# Altium schematic sheet main-arduino.SchDoc(main-arduino)
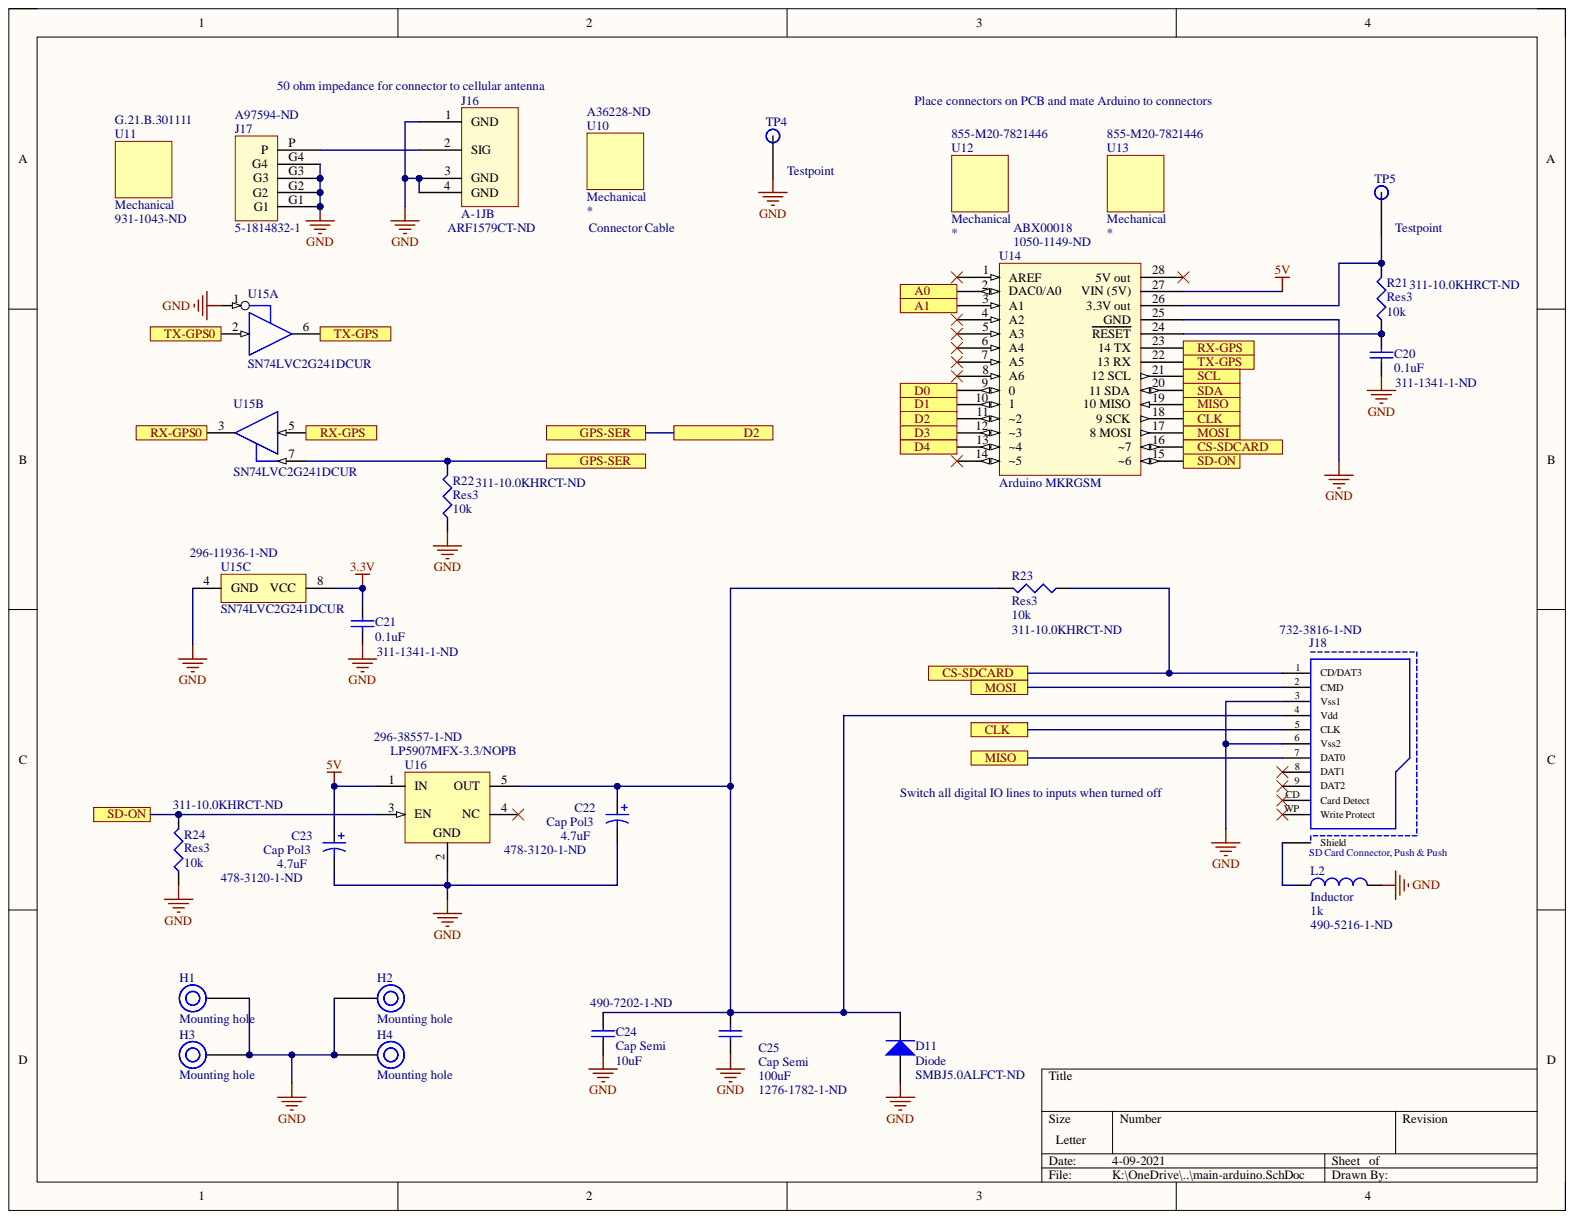
[diagram p0: main-arduino.SchDoc]

## Parts
C20  value 0.1uF  Supplier Part Number 1: 311-1341-1-ND  [1608[0603]]  (hidden comment: Cap Semi)
C21  value 0.1uF  Supplier Part Number 1: 311-1341-1-ND  [1608[0603]]  (hidden comment: Cap Semi)
C22  Cap Pol3  value 4.7uF  [1206-pol]
C23  Cap Pol3  value 4.7uF  Supplier Part Number 1: 478-3120-1-ND  [1206-pol]
C24  Cap Semi  value 10uF  Capacitance: 10uF  Supplier Part Number 1: 490-7202-1-ND  [1608[0603]]
C25  Cap Semi  value 100uF  Capacitance: 100uF  [C1206]
D11  Diode  Supplier Part Number 1: SMBJ5.0ALFCT-ND  [smbj]
H1  Mounting hole  [M3 hole]  (no BOM)
H2  Mounting hole  [M3 hole]  (no BOM)
H3  Mounting hole  [M3 hole]  (no BOM)
H4  Mounting hole  [M3 hole]  (no BOM)
J16  A-1JB  Supplier Part Number 1: ARF1579CT-ND  [A-1JB]
J17  5-1814832-1  Supplier Part Number 1: A97594-ND  [5-1814832-1]
J18  SD Card Connector, Push & Push  Supplier Part Number 1: 732-3816-1-ND  [693061010911]
L2  Inductor  value 1k  [J1-0603]
R21  Res3  value 10k  Supplier Part Number 1: 311-10.0KHRCT-ND  [J1-0603]
R22  Res3  value 10k  Supplier Part Number 1: 311-10.0KHRCT-ND  [J1-0603]
R23  Res3  value 10k  [J1-0603]
R24  Res3  value 10k  Supplier Part Number 1: 311-10.0KHRCT-ND  [J1-0603]
TP4  Testpoint  [testpoint]  (no BOM)
TP5  Testpoint  [testpoint]  (no BOM)
U10  Mechanical  Supplier Part Number 2: A36228-ND  (mechanical)
U11  Mechanical  Manufacturer Part Number: G.21.B.301111  Supplier Part Number 1: 931-1043-ND  (mechanical)
U12  Mechanical  Supplier Part Number 2: 855-M20-7821446  (mechanical)
U13  Mechanical  Supplier Part Number 2: 855-M20-7821446  (mechanical)
U14  Arduino MKRGSM  Manufacturer: Arduino  Supplier Part Number 1: 1050-1149-ND  [MKRGSM]
U15  SN74LVC2G241DCUR  [DCU0008A_M]  (parts A,B,C)
U16  LP5907MFX-3.3/NOPB  [DBV0005A_L]

## Ports
A0, A1, CLK, CS-SDCARD, D0, D1, D2, D3, D4, GPS-SER, MISO, MOSI, RX-GPS, RX-GPS0, SCL, SD-ON, SDA, TX-GPS, TX-GPS0

## Nets
3.3V: C21.1 U15.8(VCC)
5V: C23.1 U14.27(VIN_(5V)) U16.1(IN)
GND: C20.2 C21.2 C22.2 C23.2 C24.1 C25.1 D11.1 H1.1 H2.1 H3.1 H4.1 J16.1(GND) J16.3(GND) J16.4(GND) J17.G1 J17.G2 J17.G3 J17.G4 J18.3(Vss1) J18.6(Vss2) L2.2 R22.1 R24.1 TP4.1 U14.25(GND) U15.1 U15.4(GND) U16.2
A0: U14.2(DAC0/A0) ; port A0
A1: U14.3(A1) ; port A1
CLK: J18.5(CLK) U14.18(9_SCK) ; port CLK
CS-SDCARD: J18.1(CD/DAT3) R23.1 U14.16(~7) ; port CS-SDCARD
D0: U14.9 ; port D0
D1: U14.10 ; port D1
D2: R22.2 U14.11(~2) U15.7 ; port D2, port GPS-SER
D3: U14.12(~3) ; port D3
D4: U14.13(~4) ; port D4
MISO: J18.7(DAT0) U14.19(10_MISO) ; port MISO
MOSI: J18.2(CMD) U14.17(8_MOSI) ; port MOSI
RX-GPS: U14.23(14_TX) U15.5 ; port RX-GPS
RX-GPS0: U15.3 ; port RX-GPS0
SCL: U14.21(12_SCL) ; port SCL
SD-ON: R24.2 U14.15(~6) U16.3(EN) ; port SD-ON
SDA: U14.20(11_SDA) ; port SDA
TX-GPS: U14.22(13_RX) U15.6 ; port TX-GPS
TX-GPS0: U15.2 ; port TX-GPS0
NetC20_1: C20.1 R21.1 U14.24(RESET)
NetC22_1: C22.1 C24.2 C25.2 D11.2 J18.4(Vdd) R23.2 U16.5(OUT)
NetJ16_2: J16.2(SIG) J17.P
NetJ18_Shield: J18.Shield L2.1
NetR21_2: R21.2 TP5.1 U14.26(3.3V_out)

Not connected: J18 8(DAT1) 9(DAT2) CD WP(Write_Protect); U14 1(AREF) 4(A2) 5(A3) 6(A4) 7(A5) 8(A6) 14(~5) 28(5V_out); U16 4(NC)

## Library data of the parts
C20 C21 = Cap Semi: Capacitor (Semiconductor SIM Model); Manufacturer: Yageo; Manufacturer Part Number: CC0603KRX7R8BB104; Capacitance: 100nF; Dielectric: X7R; Tolerance: 10%; Voltage: 25V; Case Code (Imperial): 0603; Case Code (Metric): 1608; Case/Package: 0603; Component Kind: Standard; ComponentLink1Description: http://www.samsungsem.com/servlet/FileDownload?type=spec&file=CL10B104KB8NNNC.pdf; ComponentLink1URL: http://www.samsungsem.com/servlet/FileDownload?type=spec&file=CL10B104KB8NNNC.pdf; ComponentLink2Description: https://datasheet.ciiva.com/11786/0900766b80c10176-11786120.pdf?src-supplier=RSComponents; ComponentLink2URL: https://datasheet.ciiva.com/11786/0900766b80c10176-11786120.pdf?src-supplier=RSComponents; ComponentLink3Description: https://datasheet.ciiva.com/144/mlccorderingcode-144075.pdf?src-supplier=Digi-Key; ComponentLink3URL: https://datasheet.ciiva.com/144/mlccorderingcode-144075.pdf?src-supplier=Digi-Key; ComponentLink4Description: https://datasheet.ciiva.com/17434/upy-gphc-x7r-6-3v-to-50v-8-17434263.pdf?src-supplier=Avnet+Europe; ComponentLink4URL: https://datasheet.ciiva.com/17434/upy-gphc-x7r-6-3v-to-50v-8-17434263.pdf?src-supplier=Avnet+Europe; ComponentLink5Description: https://datasheet.ciiva.com/26829/getdatasheetpartid-403577-26829431.pdf?src-supplier=Verical; ComponentLink5URL: https://datasheet.ciiva.com/26829/getdatasheetpartid-403577-26829431.pdf?src-supplier=Verical; ComponentLink6Description: https://datasheet.ciiva.com/11587/upy-gphc-x7r-6-3v-to-50v-8-11587208.pdf?src-supplier=Avnet; ComponentLink6URL: https://datasheet.ciiva.com/11587/upy-gphc-x7r-6-3v-to-50v-8-11587208.pdf?src-supplier=Avnet; ComponentLink7Description: https://datasheet.ciiva.com/13389/upy-gphc-x7r-6-3v-to-50v-8-13389352.pdf?src-supplier=Mouser; ComponentLink7URL: https://datasheet.ciiva.com/13389/upy-gphc-x7r-6-3v-to-50v-8-13389352.pdf?src-supplier=Mouser; ComponentLink8Description: https://datasheet.ciiva.com/28450/1489800-28450584.pdf?src-supplier=Element14; ComponentLink8URL: https://datasheet.ciiva.com/28450/1489800-28450584.pdf?src-supplier=Element14; ComponentLink9Description: https://datasheet.ciiva.com/144/mlccorderingcode-144073.pdf?src-supplier=Digi-Key; ComponentLink9URL: https://datasheet.ciiva.com/144/mlccorderingcode-144073.pdf?src-supplier=Digi-Key; ComponentLink10Description: https://datasheet.ciiva.com/144/mlccorderingcode-144074.pdf?src-supplier=Digi-Key; ComponentLink10URL: https://datasheet.ciiva.com/144/mlccorderingcode-144074.pdf?src-supplier=Digi-Key; ComponentLink11Description: https://datasheet.ciiva.com/7978/1489800-7978155.pdf?src-supplier=Element14; ComponentLink11URL: https://datasheet.ciiva.com/7978/1489800-7978155.pdf?src-supplier=Element14; Composition: Ceramic; Depth: 0.8mm; Halogen Free: Halogen Free; Height: 0.8mm; Lead Free: Lead Free; Length: 1.6mm; Library Name: Miscellaneous Devices.IntLib; Max Operating Temperature: 125°C; Min Operating Temperature: -55°C; Mount: Surface Mount; Number of Pins: 2; Package Quantity: 1; Packaging: Tape and Reel; Pricing 1: 1=0.10000, 10=0.05000, 100=0.02220, 500=0.01584, 1000=0.01245 (USD); Radiation Hardening: No; REACH SVHC: Unknown; RoHS: Yes; Signal Integrity: Cap Semi; Simulation: CAP; Stock 1: 22812167; Supplier 1: Digi-Key; Termination: SMD/SMT; Thickness: 0.035inch; Voltage Rating: 25V; Voltage Rating (DC): 25V; Width: 0.8mm
C22 C23 = Cap Pol3: Polarized Capacitor (Surface Mount); Manufacturer: AVX Corporation; Manufacturer Part Number: NOJA475M010RWJ; Manufacturer Size Code: A; Dissipation Factor: 6%; Tolerance: ±20%; Category: Capacitors; Component Kind: Standard; ComponentLink1Description: http://datasheets.avx.com/NOJ.pdf; ComponentLink1URL: http://datasheets.avx.com/NOJ.pdf; Features: -; Height - Seated (Max): 0.071" (1.80mm); Library Name: Miscellaneous Devices.IntLib; Mounting Type: Surface Mount; Operating Temperature: -55°C ~ 105°C; Package / Case: 1206 (3216 Metric); Packaging: Cut Tape (CT); Part Status: Active; Pricing 1: 1=0.68, 10=0.485, 100=0.319, 500=0.2363, 1000=0.20086 (CAD); RoHS: RoHS Compliant; Signal Integrity: Cap Pol; Simulation: CAP; Size / Dimension: 0.126" L x 0.063" W (3.20mm x 1.60mm); Stock 1: 5356; Supplier 1: Digi-Key; Supplier Device Package: 1206 (3216 Metric)
  C22: Supplier Part Number 1: 478-3120-1-ND
C24 = Cap Semi: Capacitor (Semiconductor SIM Model); Manufacturer: Murata; Manufacturer Part Number: GRM188R61E106MA73D; Dielectric: X5R; Tolerance: 20%; Voltage: 25V; Case Code (Imperial): 0603; Case Code (Metric): 1608; Case/Package: 0603; Component Kind: Standard; ComponentLink1Description: http://www.yuden.co.jp/productdata/catalog/en/mlcc06_e.pdf; ComponentLink1URL: http://www.yuden.co.jp/productdata/catalog/en/mlcc06_e.pdf; ComponentLink2Description: https://datasheet.ciiva.com/25710/cl31a106kahnnne-25710837.pdf?src-supplier=Digi-Key; ComponentLink2URL: https://datasheet.ciiva.com/25710/cl31a106kahnnne-25710837.pdf?src-supplier=Digi-Key; ComponentLink3Description: https://datasheet.ciiva.com/15195/getdatasheetpartid-355210-15195990.pdf?src-supplier=Verical; ComponentLink3URL: https://datasheet.ciiva.com/15195/getdatasheetpartid-355210-15195990.pdf?src-supplier=Verical; ComponentLink4Description: https://datasheet.ciiva.com/29201/452519-da-01-en-vs-kondensator-1206-29201124.pdf?src-supplier=Conrad; ComponentLink4URL: https://datasheet.ciiva.com/29201/452519-da-01-en-vs-kondensator-1206-29201124.pdf?src-supplier=Conrad; ComponentLink5Description: https://datasheet.ciiva.com/22619/c02e-22619674.pdf?src-supplier=Element14; ComponentLink5URL: https://datasheet.ciiva.com/22619/c02e-22619674.pdf?src-supplier=Element14; ComponentLink6Description: https://datasheet.ciiva.com/22776/608985-22776426.pdf?src-supplier=Farnell; ComponentLink6URL: https://datasheet.ciiva.com/22776/608985-22776426.pdf?src-supplier=Farnell; ComponentLink7Description: https://datasheet.ciiva.com/22776/c02e-22776427.pdf?src-supplier=Farnell; ComponentLink7URL: https://datasheet.ciiva.com/22776/c02e-22776427.pdf?src-supplier=Farnell; ComponentLink8Description: https://datasheet.ciiva.com/14906/partnumbering-e-01-14906429.pdf?src-supplier=Digi-Key; ComponentLink8URL: https://datasheet.ciiva.com/14906/partnumbering-e-01-14906429.pdf?src-supplier=Digi-Key; ComponentLink9Description: https://datasheet.ciiva.com/14906/partnumbering-e-01-14906431.pdf?src-supplier=Digi-Key; ComponentLink9URL: https://datasheet.ciiva.com/14906/partnumbering-e-01-14906431.pdf?src-supplier=Digi-Key; Composition: Ceramic; Depth: 0.8mm; Height: 0.8mm; Lead Free: Lead Free; Length: 1.6mm; Library Name: Miscellaneous Devices.IntLib; Max Operating Temperature: 85°C; Min Operating Temperature: -55°C; Mount: Surface Mount; Number of Pins: 2; Package Quantity: 1; Packaging: Tape and Reel; Pricing 1: 1=0.42000, 10=0.29300, 100=0.17560, 500=0.13172, 1000=0.11123 (USD); REACH SVHC: No SVHC; RoHS: Yes; Signal Integrity: Cap Semi; Simulation: CAP; Stock 1: 278450; Supplier 1: Digi-Key; Termination: SMD/SMT; Thickness: 0.031inch; Voltage Rating: 25V; Voltage Rating (DC): 25V; Weight: 0.000222oz; Width: 0.031inch
C25 = Cap Semi: Capacitor (Semiconductor SIM Model); Manufacturer: Samsung; Manufacturer Part Number: CL31A107MQHNNNE; Dielectric: X5R; Material: Ceramic; Tolerance: 20%; Voltage: 6.3V; Case Code (Imperial): 1206; Case Code (Metric): 3216; Case/Package: 1206; Component Kind: Standard; ComponentLink1Description: https://datasheet.ciiva.com/20173/71-0886-20173093.pdf; ComponentLink1URL: https://datasheet.ciiva.com/20173/71-0886-20173093.pdf; ComponentLink2Description: https://datasheet.ciiva.com/25723/cl31a107mqhnnne-25723159.pdf; ComponentLink2URL: https://datasheet.ciiva.com/25723/cl31a107mqhnnne-25723159.pdf; ComponentLink3Description: https://datasheet.ciiva.com/16437/cl31a107mqhnnne-16437212.pdf; ComponentLink3URL: https://datasheet.ciiva.com/16437/cl31a107mqhnnne-16437212.pdf; ComponentLink4Description: https://datasheet.ciiva.com/15195/getdatasheetpartid-358449-15195997.pdf; ComponentLink4URL: https://datasheet.ciiva.com/15195/getdatasheetpartid-358449-15195997.pdf; ComponentLink5Description: https://datasheet.ciiva.com/29201/452463-da-01-en-vs-kondensator-1206-29201265.pdf; ComponentLink5URL: https://datasheet.ciiva.com/29201/452463-da-01-en-vs-kondensator-1206-29201265.pdf; Contact Plating: Tin; Depth: 1.6mm; Height: 1.6mm; LatestRevisionDate: 29-May-2009; LatestRevisionNote: IPC-7351 Footprint Added.; Length: 3.2mm; Library Name: Miscellaneous Devices.IntLib; Max Operating Temperature: 85°C; Min Operating Temperature: -55°C; Mount: Surface Mount; Package Quantity: 2000; PackageDescription: Chip Capacitor; PackageReference: C1206; Packaging: Tape and Reel; Pricing 1: 1=0.64, 10=0.456, 100=0.3001, 500=0.2223, 1000=0.189 (USD); Published: 8-Jun-2000; Radiation Hardening: No; Signal Integrity: Cap Semi; Simulation: CAP; Stock 1: 76664; Supplier 1: Digi-Key; Supplier Part Number 1: 1276-1782-1-ND; Thickness: 0.071inch; Voltage Rating: 6.3V; Width: 0.063inch; Publisher: Altium Limited
D11 = Diode: Default Diode; Manufacturer: Littelfuse; Manufacturer Part Number: SMBJ5.0A; Breakdown Voltage: 6.4V; Clamping Voltage: 9.2V; Current: 68A; Direction: Unidirectional; Element Configuration: Single; ESD Protection: Yes; Leakage Current: 800uA; Max Breakdown Voltage: 7V; Max Power Dissipation: 600W; Max Surge Current: 65.3A; Number of Channels: 1; Operating Supply Voltage: 5V; Power Line Protection: No; Test Current: 10mA; Case/Package: SMB; Component Kind: Standard; ComponentLink1Description: http://www.bourns.com/docs/Product-Datasheets/SMBJ.pdf; ComponentLink1URL: http://www.bourns.com/docs/Product-Datasheets/SMBJ.pdf; ComponentLink2Description: https://datasheet.ciiva.com/17412/ds19002-17412873.pdf; ComponentLink2URL: https://datasheet.ciiva.com/17412/ds19002-17412873.pdf; ComponentLink3Description: https://datasheet.ciiva.com/16427/ds19002-16427518.pdf; ComponentLink3URL: https://datasheet.ciiva.com/16427/ds19002-16427518.pdf; ComponentLink4Description: https://datasheet.ciiva.com/18403/70184815-18403605.pdf; ComponentLink4URL: https://datasheet.ciiva.com/18403/70184815-18403605.pdf; ComponentLink5Description: https://datasheet.ciiva.com/15143/getdatasheetpartid-117005-15143558.pdf; ComponentLink5URL: https://datasheet.ciiva.com/15143/getdatasheetpartid-117005-15143558.pdf; ComponentLink6Description: https://datasheet.ciiva.com/46381/1677318-46381958.pdf; ComponentLink6URL: https://datasheet.ciiva.com/46381/1677318-46381958.pdf; ComponentLink7Description: https://datasheet.ciiva.com/25624/0900766b810f4eeb-25624712.pdf; ComponentLink7URL: https://datasheet.ciiva.com/25624/0900766b810f4eeb-25624712.pdf; ComponentLink8Description: https://datasheet.ciiva.com/331/littelfuse-tvs-diode-smbj-datasheet-pdf-331131.pdf; ComponentLink8URL: https://datasheet.ciiva.com/331/littelfuse-tvs-diode-smbj-datasheet-pdf-331131.pdf; ComponentLink9Description: https://datasheet.ciiva.com/34302/1546929-34302459.pdf; ComponentLink9URL: https://datasheet.ciiva.com/34302/1546929-34302459.pdf; ComponentLink10Description: https://datasheet.ciiva.com/331/littelfuse-tvs-diode-smbj-datasheet-pdf-331132.pdf; ComponentLink10URL: https://datasheet.ciiva.com/331/littelfuse-tvs-diode-smbj-datasheet-pdf-331132.pdf; ComponentLink11Description: https://datasheet.ciiva.com/4508/littelfuse-tvs-diode-smbj-48653-4508124.pdf; ComponentLink11URL: https://datasheet.ciiva.com/4508/littelfuse-tvs-diode-smbj-48653-4508124.pdf; ComponentLink12Description: https://datasheet.ciiva.com/331/littelfuse-tvs-diode-smbj-datasheet-pdf-331133.pdf; ComponentLink12URL: https://datasheet.ciiva.com/331/littelfuse-tvs-diode-smbj-datasheet-pdf-331133.pdf; Height: 2.44mm; Lead Free: Lead Free; Length: 4.57mm; Library Name: Miscellaneous Devices.IntLib; Max Operating Temperature: 150°C; Max Reverse Leakage Current: 800uA; Min Breakdown Voltage: 6.4V; Min Operating Temperature: -55°C; Mount: Surface Mount; Number of Elements: 1; Number of Pins: 2; Number of Unidirectional Channels: 1; Package Quantity: 3000; Packaging: Tape and Reel; Peak Pulse Current: 65.3A; Peak Pulse Power: 600W; Polarity: Unidirectional; Power Dissipation: 600W; Pricing 1: 1=0.42, 10=0.327, 25=0.2756, 100=0.2244, 250=0.18588, 500=0.15384, 1000=0.11538 (USD); Radiation Hardening: No; REACH SVHC: Unknown; Reverse Breakdown Voltage: 6.4V; Reverse Standoff Voltage: 5V; Signal Integrity: Diode; Simulation: DIODE; Stock 1: 37178; Supplier 1: Digi-Key; Termination: SMD/SMT; Voltage: 5V; Voltage Rating (DC): 5V; Width: 3.94mm
H1-H4 = Mouting hole: Description: Mounting Hole; Library Name: holes.IntLib
J16 = A-1JB: Manufacturer: Amphenol RF; Body Material: Bronze,Liquid Crystal Polymer,Polymer; Body Plating: Silver; Connector Type: Coaxial,Male Pin; Dielectric Material: Polymer; Frequency: 6Hz; Impedance: 50R; Insulation Resistance: 500MR; Mating Cycles: 30; Max Frequency: 6GHz; Plating: Liquid Crystal Polymer,Polymer; Polarity: Standard; Component Kind: Standard; ComponentLink1Description: http://drawings.amphenolrf.com/Customer_Outline/CA-1JB.pdf; ComponentLink1URL: http://drawings.amphenolrf.com/Customer_Outline/CA-1JB.pdf; ComponentLink2Description: http://www.mouser.com/ds/2/18/CA-1JB-713210.pdf; ComponentLink2URL: http://www.mouser.com/ds/2/18/CA-1JB-713210.pdf; ComponentLink3Description: https://www.distrelec.biz/Web/Downloads/46/08/04614608.pdf?mime=application%2Fpdf; ComponentLink3URL: https://www.distrelec.biz/Web/Downloads/46/08/04614608.pdf?mime=application%2Fpdf; ComponentLink4Description: http://www.farnell.com/datasheets/689597.pdf; ComponentLink4URL: http://www.farnell.com/datasheets/689597.pdf; ComponentLink5Description: http://www.alliedelec.com/m/d/41feb3dea93af6045b471b16e58c5e32.pdf; ComponentLink5URL: http://www.alliedelec.com/m/d/41feb3dea93af6045b471b16e58c5e32.pdf; ComponentLink6Description: https://www.verical.com/server-webapp/html/getDatasheet?partID=168895; ComponentLink6URL: https://www.verical.com/server-webapp/html/getDatasheet?partID=168895; ComponentLink7Description: http://www.amphenolrf.com/media/downloads/2852/CA-1PAX113-XXXYZ.pdf; ComponentLink7URL: http://www.amphenolrf.com/media/downloads/2852/CA-1PAX113-XXXYZ.pdf; ComponentLink8Description: http://www.jameco.com/Jameco/Products/ProdDS/2036357.pdf; ComponentLink8URL: http://www.jameco.com/Jameco/Products/ProdDS/2036357.pdf; Contact Material: Brass; Contact Plating: Gold; Depth: 3mm; Fastening Type: Snap; Height: 1.244mm; Insulation Material: Polymer; Lead Free: Lead Free; Length: 2.6mm; Library Name: amphenol.IntLib; Manufacturer Part Number: A-1JB; Max Operating Temperature: 90°C; Min Operating Temperature: -40°C; Mount: Surface Mount; Orientation: Straight; Package Quantity: 1; Packaging: Tape and Reel; Pricing 1: 1 = 0.89000, 10 = 0.78500, 25 = 0.73920, 50 = 0.70840, 100 = 0.67760, 250 = 0.61600, 500 = 0.55440, 1000 = 0.49280 (USD); Radiation Hardening: No; REACH SVHC: Unknown; RoHS: Yes; Stock 1: 52082; Supplier 1: Digi-Key; Termination: Solder; Weight: 0.001058oz
J17 = 5-1814832-1: Manufacturer: TE Connectivity; Body Material: Zinc; Body Plating: Gold; Connector System: Cable-to-Board; Connector Type: SMA; Dielectric Material: PTFE; Frequency: 3GHz; Gender: Female; Impedance: 50R; Insulation Resistance: 5GR; Number of Positions: 1; Plating: Nickel; Polarity: Normal; Sealable: No (Without); Tail Length: 4mm; Wire/Cable Type: Coaxial; Case/Package: SMA; Component Kind: Standard; ComponentLink1Description: https://datasheet.ciiva.com/642/eng-cd-1814832-b1-642785.pdf?src-supplier=Digi-Key; ComponentLink1URL: https://datasheet.ciiva.com/642/eng-cd-1814832-b1-642785.pdf?src-supplier=Digi-Key; ComponentLink2Description: https://datasheet.ciiva.com/642/eng-cd-1814832-b1-642785.pdf?src-supplier=Avnet; ComponentLink2URL: https://datasheet.ciiva.com/642/eng-cd-1814832-b1-642785.pdf?src-supplier=Avnet; ComponentLink3Description: https://datasheet.ciiva.com/23783/1663582-23783560.pdf?src-supplier=Newark; ComponentLink3URL: https://datasheet.ciiva.com/23783/1663582-23783560.pdf?src-supplier=Newark; ComponentLink4Description: https://datasheet.ciiva.com/16318/5-1814832-1-16318587.pdf?src-supplier=Future+Electronics; ComponentLink4URL: https://datasheet.ciiva.com/16318/5-1814832-1-16318587.pdf?src-supplier=Future+Electronics; ComponentLink5Description: https://datasheet.ciiva.com/11762/0900766b80a70d0f-11762254.pdf?src-supplier=RSComponents; ComponentLink5URL: https://datasheet.ciiva.com/11762/0900766b80a70d0f-11762254.pdf?src-supplier=RSComponents; ComponentLink6Description: https://datasheet.ciiva.com/26824/getdatasheetpartid-396696-26824822.pdf?src-supplier=Verical; ComponentLink6URL: https://datasheet.ciiva.com/26824/getdatasheetpartid-396696-26824822.pdf?src-supplier=Verical; ComponentLink7Description: https://datasheet.ciiva.com/30201/eng-cd-1814832-a-30201302.pdf?src-supplier=Onlinecomponents.com; ComponentLink7URL: https://datasheet.ciiva.com/30201/eng-cd-1814832-a-30201302.pdf?src-supplier=Onlinecomponents.com; ComponentLink8Description: https://datasheet.ciiva.com/25908/eng-cd-1814832-a-25908583.pdf?src-supplier=Avnet+Europe; ComponentLink8URL: https://datasheet.ciiva.com/25908/eng-cd-1814832-a-25908583.pdf?src-supplier=Avnet+Europe; ComponentLink9Description: https://datasheet.ciiva.com/16469/eng-cd-1814832-a-16469256.pdf?src-supplier=Avnet+Asia; ComponentLink9URL: https://datasheet.ciiva.com/16469/eng-cd-1814832-a-16469256.pdf?src-supplier=Avnet+Asia; Contact Material: Bronze; Contact Plating: Gold; Depth: 6.4mm; Description: 5-1814832-1; ELV: Compliant; Fastening Type: Threaded; Insulation Material: Polytetrafluoroethylene; Lead Free: Lead Free; Length: 0.532inch; Library Name: TE.IntLib; Manufacturer Part Number: 5-1814832-1; Max Frequency: 3GHz; Max Operating Temperature: 185°C; Min Operating Temperature: -67°C; Mount: Through Hole; Orientation: Straight; Package Quantity: 1; Packaging: Box; Pricing 1: 1 = 1.99000, 10 = 1.80400, 25 = 1.69360, 50 = 1.62000, 100 = 1.54640, 250 = 1.39908, 500 = 1.28864, 1000 = 1.10454 (USD); Radiation Hardening: No; REACH SVHC: No SVHC; RoHS: Yes; Stock 1: 34232; Supplier 1: Digi-Key; Termination: Through Hole
J18 = 693061010911: WR-CRD SD Card Connector, Push & Push, with Card Detection; Manufacturer: Wurth Electronics; Connector Type: Connector,Socket; Number of Contacts: 9; Rated Current: 0.5A; Working Voltage: 500V AC; Component Kind: Standard; ComponentLink1Description: Manufacturer; ComponentLink1URL: http://www.we-online.de; ComponentLink2Description: Datasheet; ComponentLink2URL: http://katalog.we-online.de/em/datasheet/693061010911.pdf; ComponentLink3Description: https://datasheet.ciiva.com/6935/693061010911-6935172.pdf; ComponentLink3URL: https://datasheet.ciiva.com/6935/693061010911-6935172.pdf; ComponentLink4Description: https://datasheet.ciiva.com/25605/0900766b81113f30-25605368.pdf; ComponentLink4URL: https://datasheet.ciiva.com/25605/0900766b81113f30-25605368.pdf; ComponentLink5Description: https://datasheet.ciiva.com/6935/693061010911-6935165.pdf; ComponentLink5URL: https://datasheet.ciiva.com/6935/693061010911-6935165.pdf; Contact Material: Copper,Gold,Copper Alloy; Contact Plating: Gold; Height: 2.85mm; Lead Free: Lead Free; Length: 28.8mm; Library Name: ALTIUM-WR-CRD-rev18a.IntLib; Manufacturer Part Number: 693061010911; Max Operating Temperature: 85°C; Min Operating Temperature: -25°C; Mount: Surface Mount; Mounting Technology: SMT; Operating Temperature: -25 to 85 degC; Package Quantity: 1; Packaging: Cut Tape; Part Number: 693061010911; Pricing 1: 1=5.01, 10=4.9, 50=4.312, 100=4.116, 200=3.528 (USD); Published Date: Feb. 2018; REACH SVHC: Unknown; Stock 1: 1087; Supplier 1: Digi-Key; Termination: SMD/SMT; Width: 28.9mm; Publisher: Wurth Elektronik
L2 = Inductor: Manufacturer: Murata Electronics North America; Manufacturer Part Number: BLM18HE152SN1D; Code_IEC: 1005; Current Rating (Max): 500mA; DC Resistance (DCR) (Max): 500 mOhm; Filter Type: Signal Line; Impedance @ Frequency: 1.5 kOhm @ 100MHz; Number of Lines: 1; Category: Filters; Code_JEITA: 0402; Component Kind: Standard; ComponentLink1Description: http://www.murata.com/en-us/products/productdata/8796741599262/ENFA0004.pdf; ComponentLink1URL: http://www.murata.com/en-us/products/productdata/8796741599262/ENFA0004.pdf; Description: Inductor; Height (Max): 0.037" (0.95mm); LatestRevisionDate: 29-May-2009; LatestRevisionNote: IPC-7351 Footprint Added.; Library Name: Miscellaneous Devices.IntLib; Mounting Type: Surface Mount; Operating Temperature: -55°C ~ 125°C; Package / Case: 0603 (1608 Metric); PackageDescription: Chip Inductor; PackageReference: 0402-A; Packaging: Cut Tape (CT); Part Status: Active; Pricing 1: 1=0.28, 10=0.215, 25=0.1776, 50=0.1532, 100=0.1378, 250=0.1164, 500=0.10416, 1000=0.08884 (CAD); Published: 8-Jun-2000; Ratings: -; RoHS: RoHS Compliant; Signal Integrity: Inductor; Simulation: INDUCTOR; Size / Dimension: 0.063" L x 0.032" W (1.60mm x 0.80mm); Stock 1: 303514; Supplier 1: Digi-Key; Supplier Part Number 1: 490-5216-1-ND; Publisher: Altium Limited
R21-R24 = Res3: Resistor; Manufacturer: Yageo; Manufacturer Part Number: RC0603FR-0710KL; Power Rating: 100mW; Resistance: 10kR; Temperature Coefficient: 100ppm/°C; Tolerance: 1%; Voltage Rating: 75V; Voltage Rating (DC): 50V; Case Code (Imperial): 0603; Case Code (Metric): 1608; Case/Package: 0603; Component Kind: Standard; ComponentLink1Description: https://datasheet.ciiva.com/7660/38590-7660789.pdf?src-supplier=Element14; ComponentLink1URL: https://datasheet.ciiva.com/7660/38590-7660789.pdf?src-supplier=Element14; ComponentLink2Description: https://datasheet.ciiva.com/15189/getdatasheetpartid-409289-15189591.pdf?src-supplier=Verical; ComponentLink2URL: https://datasheet.ciiva.com/15189/getdatasheetpartid-409289-15189591.pdf?src-supplier=Verical; ComponentLink3Description: https://datasheet.ciiva.com/46440/1868787-46440891.pdf?src-supplier=Element14; ComponentLink3URL: https://datasheet.ciiva.com/46440/1868787-46440891.pdf?src-supplier=Element14; ComponentLink4Description: https://datasheet.ciiva.com/7983/443971-7983596.pdf?src-supplier=Element14; ComponentLink4URL: https://datasheet.ciiva.com/7983/443971-7983596.pdf?src-supplier=Element14; ComponentLink5Description: https://datasheet.ciiva.com/7746/1738695-7746239.pdf?src-supplier=Element14; ComponentLink5URL: https://datasheet.ciiva.com/7746/1738695-7746239.pdf?src-supplier=Element14; ComponentLink6Description: https://datasheet.ciiva.com/22747/443971-22747519.pdf?src-supplier=Element14; ComponentLink6URL: https://datasheet.ciiva.com/22747/443971-22747519.pdf?src-supplier=Element14; Composition: Thick Film; Depth: 0.8mm; Height: 0.45mm; LatestRevisionDate: 29-May-2009; LatestRevisionNote: IPC-7351 Footprint Added.; Lead Free: Lead Free; Lead Pitch: 0.3mm; Length: 1.6mm; Library Name: Miscellaneous Devices.IntLib; Max Operating Temperature: 155°C; Military Standard: Not; Min Operating Temperature: -55°C; Number of Pins: 2; PackageDescription: Chip Resistor; PackageReference: J1-0603; Packaging: Tape and Reel; Pricing 1: 1 = 0.10000, 10 = 0.02400, 100 = 0.00970, 1000 = 0.00437, 2500 = 0.00379 (USD); Published: 8-Jun-2000; REACH SVHC: No SVHC; RoHS: Yes; Signal Integrity: Res; Simulation: RESISTOR; Stock 1: 90146690; Supplier 1: Digi-Key; Termination: SMD/SMT; Weight: 7.1E-05oz; Width: 0.8mm; Publisher: Altium Limited
  R23: Supplier Part Number 1: 311-10.0KHRCT-ND
TP4 TP5 = SMT Testpoint: Description: SMT Testpoint; Library Name: testpoints.IntLib
U10 = Mechanical part: Manufacturer: TE Connectivity; Manufacturer Part Number: 2015357-3; Cable Length: 0.1m; Connector Type A: Plug; Frequency: 6GHz; Gender: Female to Female; Impedance: 50R; Max Voltage Rating (AC): 60V; Wire/Cable Diameter: 0.054inch; Wire/Cable Type: Coaxial; Color: Grey; ComponentLink1Description: https://datasheet.ciiva.com/30140/eng-ds-1-1773441-5-1207-30140424.pdf?src-supplier=Onlinecomponents.com; ComponentLink1URL: https://datasheet.ciiva.com/30140/eng-ds-1-1773441-5-1207-30140424.pdf?src-supplier=Onlinecomponents.com; ComponentLink2Description: https://datasheet.ciiva.com/23/eng-ds-1-1773441-5-1207-23912.pdf?src-supplier=Digi-Key; ComponentLink2URL: https://datasheet.ciiva.com/23/eng-ds-1-1773441-5-1207-23912.pdf?src-supplier=Digi-Key; Connector Type B: Plug; Contact Plating: Gold; Description: Mechanical Part; ELV: Compliant; Features: Shielded; Length: 3.94inch; Library Name: mechanical.IntLib; Max Frequency: 6GHz; Max Operating Temperature: 90°C; Min Operating Temperature: -40°C; Mount: Cable; Package Quantity: 50; Packaging: Bulk; Pricing 2: 1 = 2.48000, 10 = 2.24700, 25 = 2.10920, 50 = 2.01760, 100 = 1.92590, 250 = 1.74248, 500 = 1.60492, 1000 = 1.37565, 2500 = 1.28394 (USD); Radiation Hardening: No; RoHS: Yes; Stock 2: 61484; Supplier 2: Digi-Key
U11 = Mechanical part: Manufacturer: Taoglas; Frequency: 900MHz; Impedance: 50R; Max Frequency: 2.17GHz; Number of Bands: 5; ComponentLink1Description: https://datasheet.ciiva.com/2792/g2120hercules20genii20penta-band20cellular20antenna20110310-2792514.pdf?src-supplier=Digi-Key; ComponentLink1URL: https://datasheet.ciiva.com/2792/g2120hercules20genii20penta-band20cellular20antenna20110310-2792514.pdf?src-supplier=Digi-Key; Description: Mechanical Part; Height: 1.14inch; Lead Free: Lead Free; Library Name: mechanical.IntLib; Mount: Screw; Package Quantity: 10; Packaging: Bulk; RoHS: Yes; Stock 2: 0; Supplier 2: Avnet; Supplier Part Number 2: G.21.B.301111; Termination: SMA
U12 U13 = Mechanical part: Manufacturer: Harwin; Manufacturer Part Number: M20-7821446; Connector Type: Receptacle; Contact Resistance: 20mR; Gender: Female; Max Current Rating: 3A; Number of Positions: 14; Pitch: 2.54mm; Case/Package: SIL; Color: Black; ComponentLink1Description: https://datasheet.ciiva.com/26948/getdatasheetpartid-384155-26948941.pdf?src-supplier=Verical; ComponentLink1URL: https://datasheet.ciiva.com/26948/getdatasheetpartid-384155-26948941.pdf?src-supplier=Verical; Contact Gender: Female; Contact Material: Bronze; Contact Plating: Tin; Depth: 2.5mm; Description: Mechanical Part; Housing Color: Black; Housing Material: Polyamide; Length: 35.96mm; Library Name: mechanical.IntLib; Max Operating Temperature: 105°C; Min Operating Temperature: -40°C; Mount: Through Hole; Number of Rows: 1; Orientation: Straight; Package Quantity: 16; Packaging: Rail/Tube; Pricing 2: 1 = 1.44000, 10 = 1.17000, 50 = 1.17000, 100 = 1.12000, 1000 = 0.79500, 10000 = 0.67900 (USD); RoHS: Yes; Stock 2: 2861; Supplier 2: Mouser; Termination: Solder
U14 = Arduino MKRGSM: Arduino MKRGSM v2.2 board (800 mil spacing); Manufacturer Part Number: ABX00018; Component Kind: Standard; ComponentLink1Description: http://datasheet.octopart.com/ABX00018-Arduino-datasheet-102038264.pdf?src-supplier=Newark; ComponentLink1URL: http://datasheet.octopart.com/ABX00018-Arduino-datasheet-102038264.pdf?src-supplier=Newark; Library Name: Arduino.IntLib; Pricing 1: 1 = 71.13000 (USD); Stock 1: 375; Supplier 1: Digi-Key
U15 = CMP-0859-00580-3: Dual Buffer/Driver With 3-State Outputs, DCU0008A, LARGE T&R; Manufacturer: Texas Instruments; 3-State Output: Yes; Bits(#): 2; F @ Nom Voltage(Max)(Mhz): 150; ICC @ Nom Voltage(Max)(mA): 0.01; Input Type: CMOS/TTL; Logic: True; Output Drive (IOL/IOH)(Max)(mA): 32/-32; Output Type: CMOS; Rating: Catalog; Schmitt Trigger: No; Sub-Family: Non-Inverting Buffer/Driver; Technology Family: LVC; tpd @ Nom Voltage(Max)(ns): 8.8,4.8,4.3,3.7; VCC(Max)(V): 5.5; VCC(Min)(V): 1.65; Voltage(Nom)(V): 1.8,2.5,3.3,5; Component Kind: Standard; DatasheetVersion: SCES210N; Generic Part Number: SN74LVC2G241; Library Name: Altium Content Vault; Operating Temperature Range(C): -40 to 125; PackageReference: DCU0008A
U16 = CMP-2000-07464-1: Ultra-Low-Noise, Low-IQ LDO, 0.25 A, Fixed 3.3 V Output, 2.2 to 5.5 V Input, -40 to 125 degC, 5-Pin SOT-23 (DBV), Green (RoHS & no Sb/Br), Tape and Reel; Manufacturer: Texas Instruments; Accuracy: 2%; Dropout Voltage: 250mV; Max Input Voltage: 5.5V; Max Output Current: 250mA; Min Input Voltage: 2.2V; Nominal Output Voltage: 3.3V; Number of Outputs: 1; Package Version: 4073253-4/N, 12/2014; Polarity: Positive; Power Supply Rejection Ratio (PSRR): 82dB; Quiescent Current: 425uA; Case/Package: SOT-23; Case\/Package: SOT-23; Component Kind: Standard; ComponentLink1Description: https://datasheet.ciiva.com/15083/lp5907-15083592.pdf?src-supplier=Digi-Key; ComponentLink1URL: https://datasheet.ciiva.com/15083/lp5907-15083592.pdf?src-supplier=Digi-Key; ComponentLink2Description: https://datasheet.ciiva.com/48475/lp5907-48475084.pdf?src-supplier=Avnet; ComponentLink2URL: https://datasheet.ciiva.com/48475/lp5907-48475084.pdf?src-supplier=Avnet; Contact Plating: Tin; Datasheet URL: http://www.ti.com/lit/ds/symlink/lp5907.pdf; Datasheet Version: Rev. L, 09/2016; Lead Free: LeadFree; Library Name: Altium Content Vault; Manufacturer Part Number: LP5907MFX-3.3/NOPB; Manufacturer URL: http://www.ti.com/; Max Operating Temperature: 125degC; Min Operating Temperature: -40degC; Mount: SurfaceMount; Mounting Technology: SurfaceMount; Number of Pins: 5; Number of Regulators: 1; Output Current: 250mA; Output Type: Fixed; Output Voltage: 3.3V; Output Voltage Accuracy: 2%; Package Description: 5-Pin Small Outline Transistor, Body 2.9 x 1.6 mm, Pitch 0.95 mm; Package Quantity: 3000; Package Reference: DBV5; Package URL: http://www.ti.com/lit/ml/mpds018l/mpds018l.pdf; Packaging: TapeandReel; Pricing 1: 1 = 0.55000, 10 = 0.47500, 100 = 0.35470, 500 = 0.27872, 1000 = 0.21537 (USD); Radiation Hardening: No; REACH SVHC: NoSVHC; RoHS: Yes; RoHSCompliant: true; Stock 1: 414376; Supplier 1: Digi-Key; Supplier Part Number 1: 296-38557-1-ND
